# Altium schematic sheet 01_MRWOLF.SchDoc
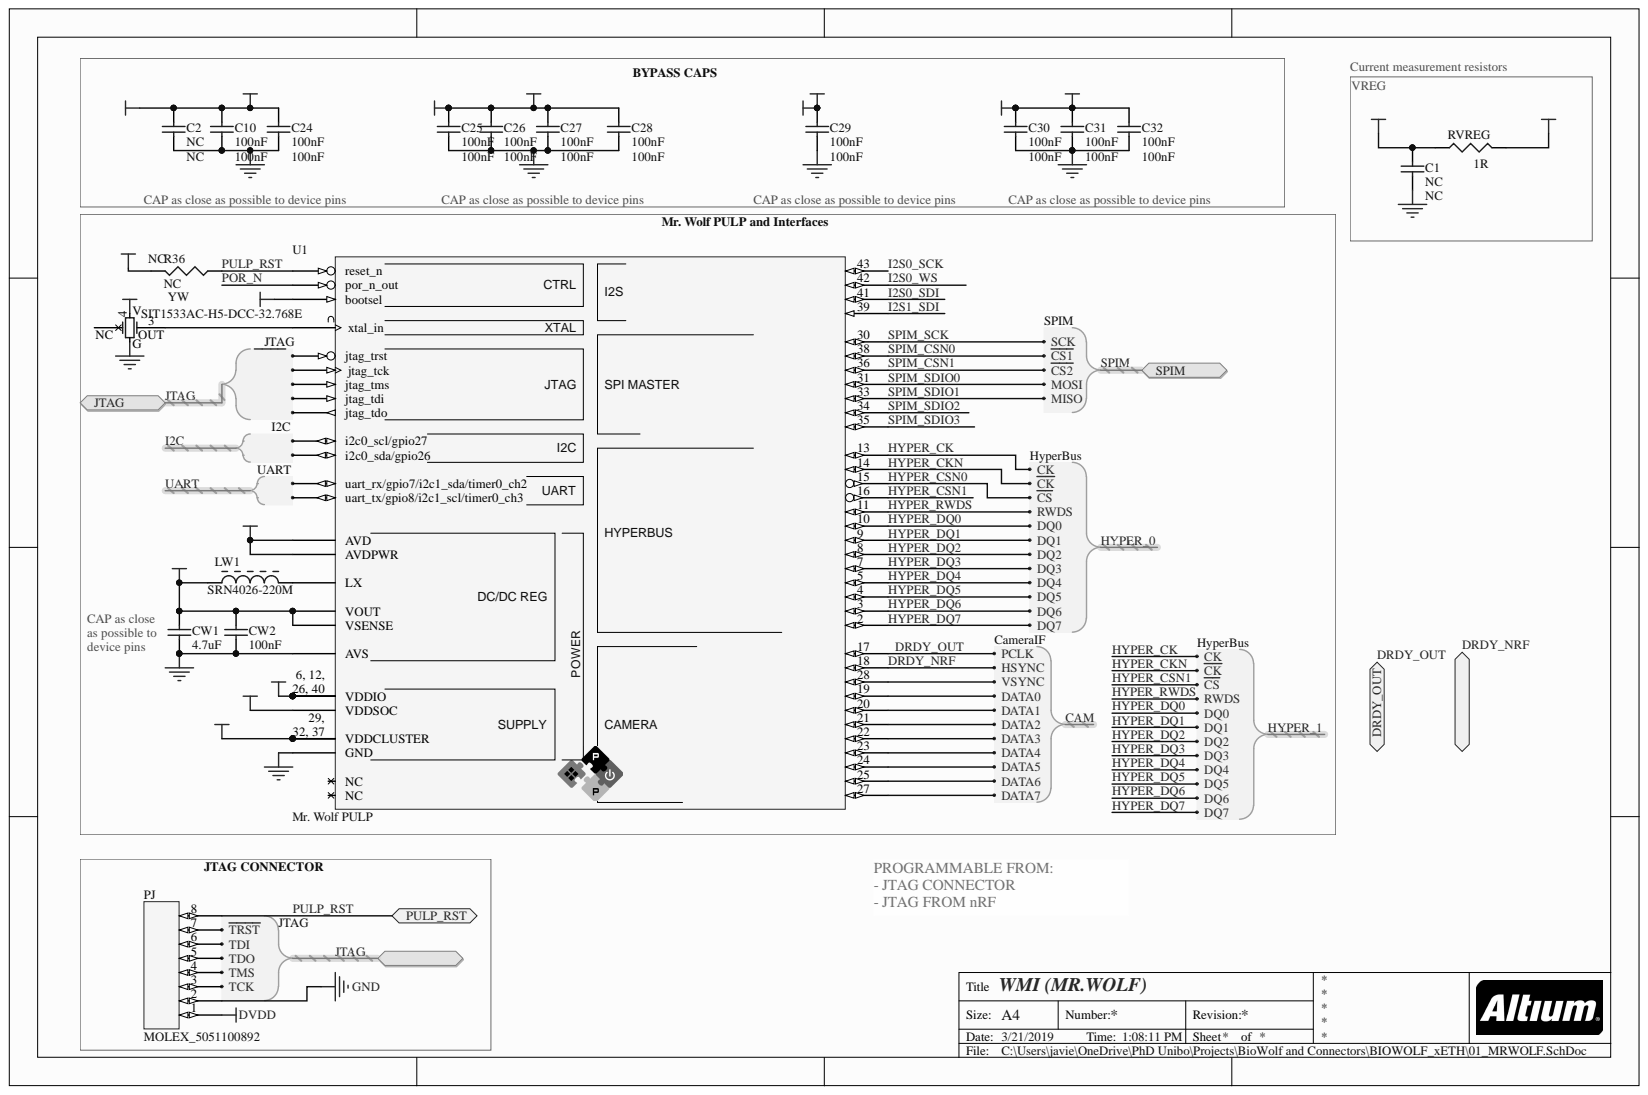
[diagram p0: 01_MRWOLF.SchDoc]

## Parts
C1  NC  [J1-0603]
C2  NC  [J1-0603]
C10  100nF  [0402]
C24  100nF  [0402]
C25  100nF  [0402]
C26  100nF  [0402]
C27  100nF  [0402]
C28  100nF  [0402]
C29  100nF  [0402]
C30  100nF  [0402]
C31  100nF  [0402]
C32  100nF  [0402]
CW1  4.7uF  [0402]
CW2  100nF  [0402]
LW1  SRN4026-220M  [1212_PCK]
PJ  MOLEX_5051100892  [MOLEX_5051100892]
R36  NC  [0402]
RVREG  value 1R  [J1-0603]
U1  Mr. Wolf PULP  [QFN50P900X900X100_HS_NOVIAS_65M]
YW  SIT1533AC-H5-DCC-32.768E  [SiT1533-C]

## Ports
DRDY_NRF, DRDY_OUT, JTAG, JTAG.TCK, JTAG.TDI, JTAG.TDO, JTAG.TMS, JTAG.~{TRST}, PULP_RST, SPIM, SPIM.MISO, SPIM.MOSI, SPIM.SCK, SPIM.~{CS1}, SPIM.~{CS2}

## Nets
DVDD: C2.2 C10.2 C24.2 C25.2 C26.2 C27.2 C28.2 PJ.1 R36.2 RVREG.2 U1.1(bootsel) U1.6 U1.12(VDDIO) U1.26 U1.40 YW.4
GND: C1.1 C2.1 C10.1 C24.1 C25.1 C26.1 C27.1 C28.1 C29.1 C30.1 C31.1 C32.1 CW1.1 CW2.1 PJ.2 U1.49(AVS) U1.65(GND) YW.2
VAVD: C1.2 RVREG.1 U1.52(AVD) U1.53(AVDPWR)
VCLU: C29.2 C30.2 C31.2 C32.2 CW1.2 CW2.2 LW1.1 U1.29(VDDCLUSTER) U1.32 U1.37 U1.54(VSENSE) U1.56(VOUT) U1.61(VDDSOC)
CAM.DATA0: U1.19
CAM.DATA1: U1.20
CAM.DATA2: U1.21
CAM.DATA3: U1.22
CAM.DATA4: U1.23
CAM.DATA5: U1.24
CAM.DATA6: U1.25
CAM.DATA7: U1.27
CAM.HSYNC: U1.18 ; port DRDY_NRF
CAM.PCLK: U1.17 ; port DRDY_OUT
CAM.VSYNC: U1.28
HYPER_0.~{CK}: U1.14
HYPER_0.~{CS}: U1.15
HYPER_0.CK: U1.13
HYPER_0.DQ0: U1.10
HYPER_0.DQ1: U1.9
HYPER_0.DQ2: U1.8
HYPER_0.DQ3: U1.7
HYPER_0.DQ4: U1.5
HYPER_0.DQ5: U1.4
HYPER_0.DQ6: U1.3
HYPER_0.DQ7: U1.2
HYPER_0.RWDS: U1.11
HYPER_1.~{CS}: U1.16
I2C.SCL: U1.63(i2c0_scl/gpio27)
I2C.SDA: U1.64(i2c0_sda/gpio26)
I2S0_SCK: U1.43
I2S0_SDI: U1.41
I2S0_WS: U1.42
I2S1_SDI: U1.39
JTAG.~{TRST}: PJ.7 U1.48(jtag_trst) ; port JTAG
JTAG.TCK: PJ.3 U1.44(jtag_tck) ; port JTAG
JTAG.TDI: PJ.6 U1.47(jtag_tdi) ; port JTAG
JTAG.TDO: PJ.5 U1.46(jtag_tdo) ; port JTAG
JTAG.TMS: PJ.4 U1.45(jtag_tms) ; port JTAG
POR_N: U1.57(por_n_out)
PULP_RST: PJ.8 R36.1 U1.60(reset_n) ; port PULP_RST
SPIM.~{CS1}: U1.38 ; port SPIM
SPIM.~{CS2}: U1.36 ; port SPIM
SPIM.MISO: U1.33 ; port SPIM
SPIM.MOSI: U1.31 ; port SPIM
SPIM.SCK: U1.30 ; port SPIM
SPIM_SDIO2: U1.34
SPIM_SDIO3: U1.35
UART.RX: U1.58(uart_rx/gpio7/i2c1_sda/timer0_ch2)
UART.TX: U1.59(uart_tx/gpio8/i2c1_scl/timer0_ch3)
NetLW1_2: LW1.2 U1.50(LX)
NetU1_62: U1.62(xtal_in) YW.3

Not connected: U1 51(NC) 55(NC); YW 1

## Library data of the parts
C1 C2 C10 C24-C32 = Cap Semi: Capacitor (Semiconductor SIM Model); Component Kind: Standard; LatestRevisionDate: 29-May-2009; LatestRevisionNote: IPC-7351 Footprint Added.; Library Name: Miscellaneous Devices.IntLib; PackageDescription: Chip Capacitor; PackageReference: C1206; Published: 8-Jun-2000; Signal Integrity: Cap Semi; Simulation: CAP; Publisher: Altium Limited
  C1 C2: Value: NC
  C10 C24-C32: Value: 100nF
CW1 CW2 = Cap Semi: Capacitor (Semiconductor SIM Model); Value: 100pF; Component Kind: Standard; LatestRevisionDate: 29-May-2009; LatestRevisionNote: IPC-7351 Footprint Added.; Library Name: Miscellaneous Devices.IntLib; PackageDescription: Chip Capacitor; PackageReference: C1206; Published: 8-Jun-2000; Signal Integrity: Cap Semi; Simulation: CAP; Publisher: Altium Limited
LW1 = BOUR-IND-SRN4026-220M: Semi-shielded Power Inductor, 22uH, 3A; Manufacturer: Bourns; Core Material: Ferrite; Current Rating: 670mA; DC Resistance (DCR): 234mR; Inductance Tolerance: 20%; Self Resonant Frequency: 16MHz; Test Frequency: 100kHz; Case/Package: Nonstandard; Component Kind: Standard; ComponentLink1Description: Datasheet; ComponentLink1URL: https://www.bourns.com/docs/Product-Datasheets/SRN4026.pdf; ComponentLink2Description: https://datasheet.ciiva.com/48684/srn4026-48684665.pdf; ComponentLink2URL: https://datasheet.ciiva.com/48684/srn4026-48684665.pdf; ComponentLink3Description: https://datasheet.ciiva.com/46641/70371276-46641103.pdf; ComponentLink3URL: https://datasheet.ciiva.com/46641/70371276-46641103.pdf; Composition: Wirewound; Depth: 4mm; Height: 2.5mm; Height - Seated (Max): 0.098inch; Inductance: 22uh; Length: 4mm; Manufacturer Part Number: SRN4026-220M; Max DC Current: 670mA; Max Operating Temperature: 125°C; Min Operating Temperature: -40°C; Mount: Surface Mount; Package Quantity: 3000; Packaging: Tape and Reel; Pricing 1: 100=0.209, 500=0.176, 3000=0.135, 6000=0.132 (EUR); Pricing 2: 5=0.298, 50=0.217, 250=0.175, 500=0.16, 1500=0.155, 3000=0.151, 15000=0.146 (EUR); Pricing 3: 3000=0.144, 6000=0.1395, 15000=0.135, 30000=0.133 (USD); Pricing 4: 1=0.41, 10=0.362, 100=0.2588, 500=0.2174, 1000=0.176 (USD); Pricing 5: 1=0.36, 10=0.246, 50=0.246, 100=0.224, 1000=0.152, 10000=0.122 (USD); Pricing 6: 1=0.41, 10=0.362, 100=0.2588, 500=0.2174, 1000=0.176 (USD); Pricing 7: 10=0.227, 100=0.216, 500=0.19, 3000=0.13, 6000=0.12 (GBP); Pricing 8: 1=0.6667, 10=0.5, 100=0.3333, 500=0.2857 (EUR); Pricing 9: 50=0.209, 250=0.165, 500=0.15, 1500=0.122, 3000=0.117, 15000=0.106, 30000=0.104 (GBP); Pricing 10: 1=0.214, 10=0.1299, 50=0.1266, 250=0.1203, 500=0.1173, 2500=0.1145 (EUR); Pricing 11: 10=0.227, 100=0.216 (GBP); REACH SVHC: No SVHC; RMS Current (Irms): 670mA; Saturation Current: 670mA; Shielding: Shielded; Stock 1: 2770; Stock 2: 402; Stock 3: 0; Stock 4: 863; Stock 5: 140; Stock 6: 863; Stock 7: 1990; Stock 8: 3000; Stock 9: 930; Stock 10: 2895; Stock 11: 2000; Supplier 1: RSComponents; Supplier 2: Farnell; Supplier 3: Digi-Key; Supplier 4: Digi-Key; Supplier 5: Mouser; Supplier 6: Digi-Key; Supplier 7: RSComponents; Supplier 8: Distrelec; Supplier 9: Farnell; Supplier 10: Distrelec; Supplier 11: RSComponents; Supplier Part Number 1: 813-5643P; Supplier Part Number 2: 2428221; Supplier Part Number 3: SRN4026-220MTR-ND; Supplier Part Number 4: SRN4026-220MDKR-ND; Supplier Part Number 5: 652-SRN4026-220M; Supplier Part Number 6: SRN4026-220MCT-ND; Supplier Part Number 7: 8135643; Supplier Part Number 8: SRN4026-220M; Supplier Part Number 9: 2428221RL; Supplier Part Number 10: 30026188; Supplier Part Number 11: 813-5643; Termination: SMD/SMT; Tolerance: 20%; Weight: 0.004938oz; Width: 4mm; Library Name: smach_lib.IntLib
R36 RVREG = Res3: Resistor; Component Kind: Standard; LatestRevisionDate: 29-May-2009; LatestRevisionNote: IPC-7351 Footprint Added.; Library Name: Miscellaneous Devices.IntLib; PackageDescription: Chip Resistor; PackageReference: J1-0603; Published: 8-Jun-2000; Signal Integrity: Res; Simulation: RESISTOR; Publisher: Altium Limited
  R36: Value: NC
U1 = PULP_MR_WOLF: Mr. Wolf PULP Heterogeneous Multi-Core System-On-Chip; Manufacturer: Aries Alectronics Inc.; Manufacturer Part Num: 23017; Part Class: Connectors; .checked_Footprint: 140604-11/scd; Num Pins: 64 (+9); Component Kind: Standard; Library Name: smach_lib.IntLib
YW = SIT-OSC32KHZ-SIT1533AC-H5-DCC-32.768: 20 ppm, Ultra-Low Power 32.768 kHz Quartz XTAL Replacement; Current - Supply (max): 1.3uA; Component Kind: Standard; ComponentLink1Description: Datasheet; ComponentLink1URL: http://www.sitime.com/products/datasheets/sit1533/SiT1533-datasheet.pdf; Frequency: 32.768kHz; Supplier 1: Digi-Key; Supplier 2: Digi-Key; Supplier 3: Digi-Key; Supplier 4: Digi-Key; Supplier 5: Digi-Key; Supplier 6: Digi-Key; Supplier 7: Digi-Key; Supplier 8: Digi-Key; Supplier Part Number 1: 1473-1515-2-ND; Supplier Part Number 2: 1473-1515-1-ND; Supplier Part Number 3: 1473-1515-6-ND; Supplier Part Number 4: 1473-1516-2-ND; Supplier Part Number 5: 1473-1516-1-ND; Supplier Part Number 6: 1473-1516-6-ND; Supplier Part Number 7: SIT1533AC-H5-DCC-32.768S-ND; Supplier Part Number 8: SIT1533AC-H5-DCC-32.768D-ND; Library Name: smach_lib.intlib
PJ = MOLEX_5051100892: Component Kind: Standard; Library Name: Schlib1.SchLib
